# Altium schematic sheet COMMUNICATION.SchDoc
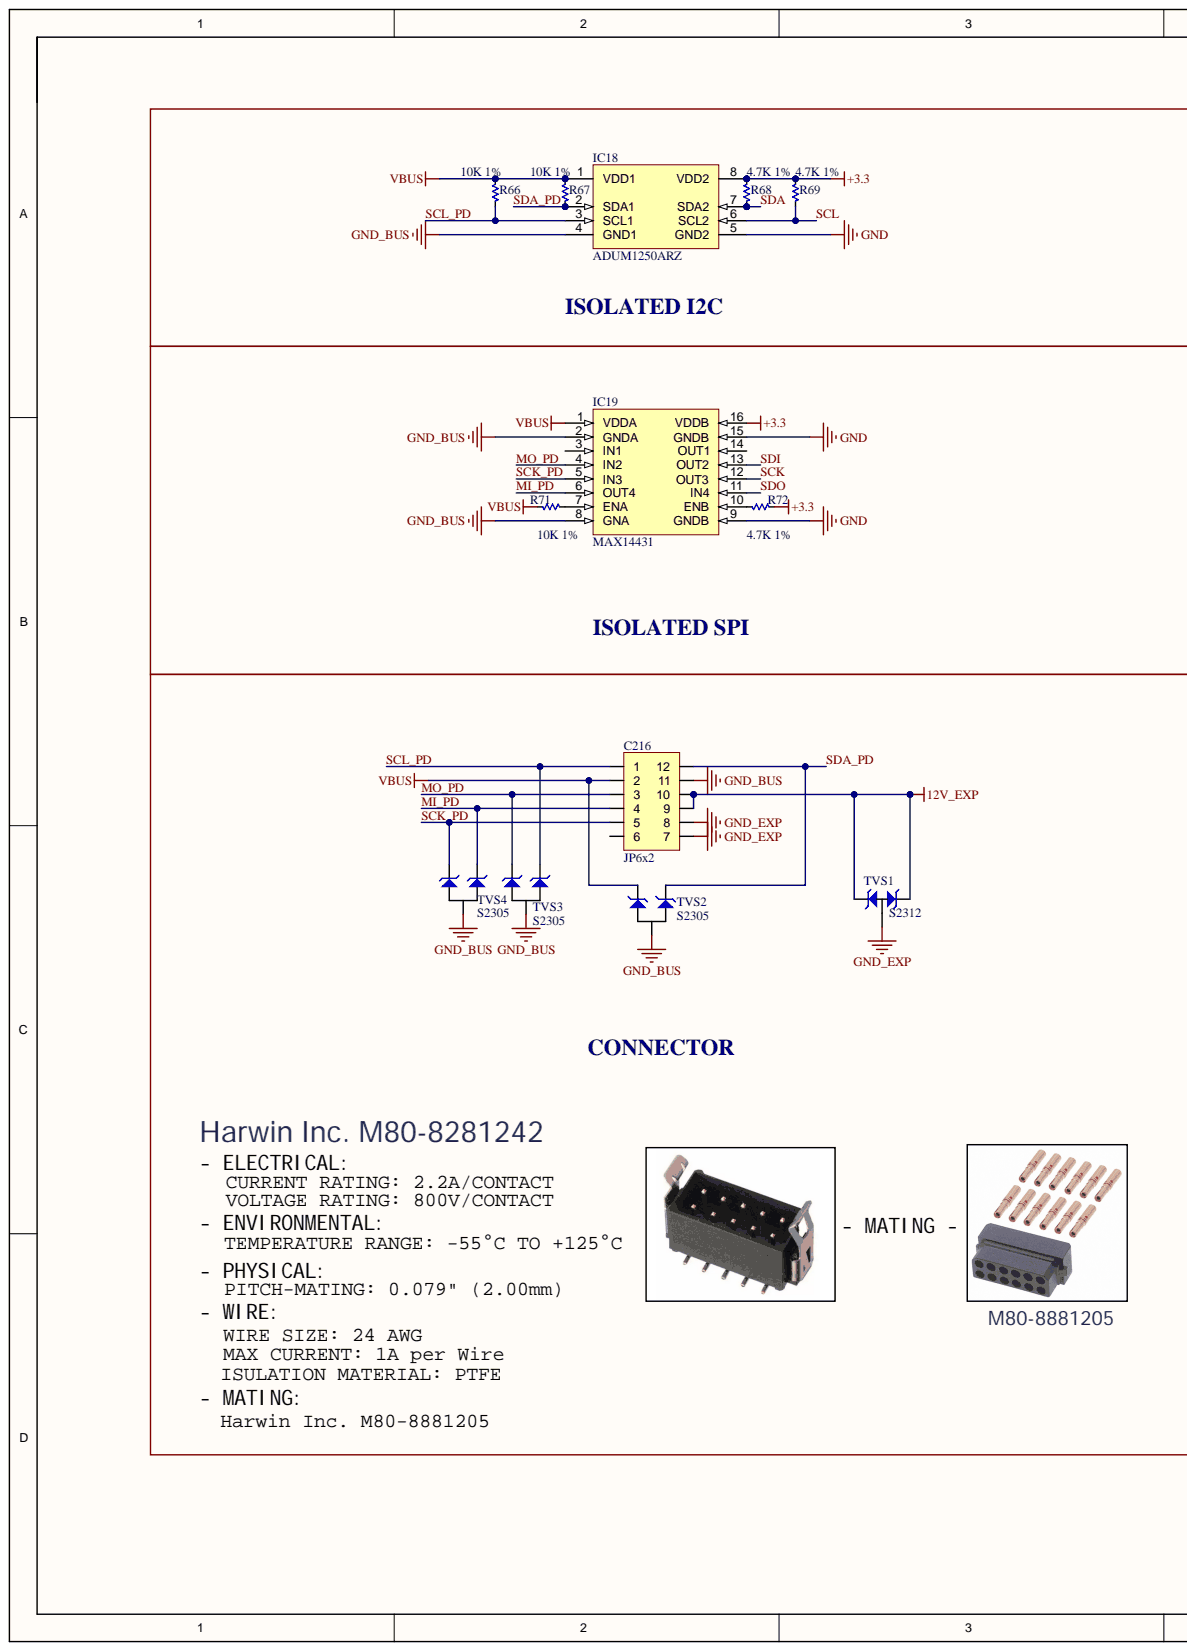
[diagram p0: COMMUNICATION.SchDoc, left]
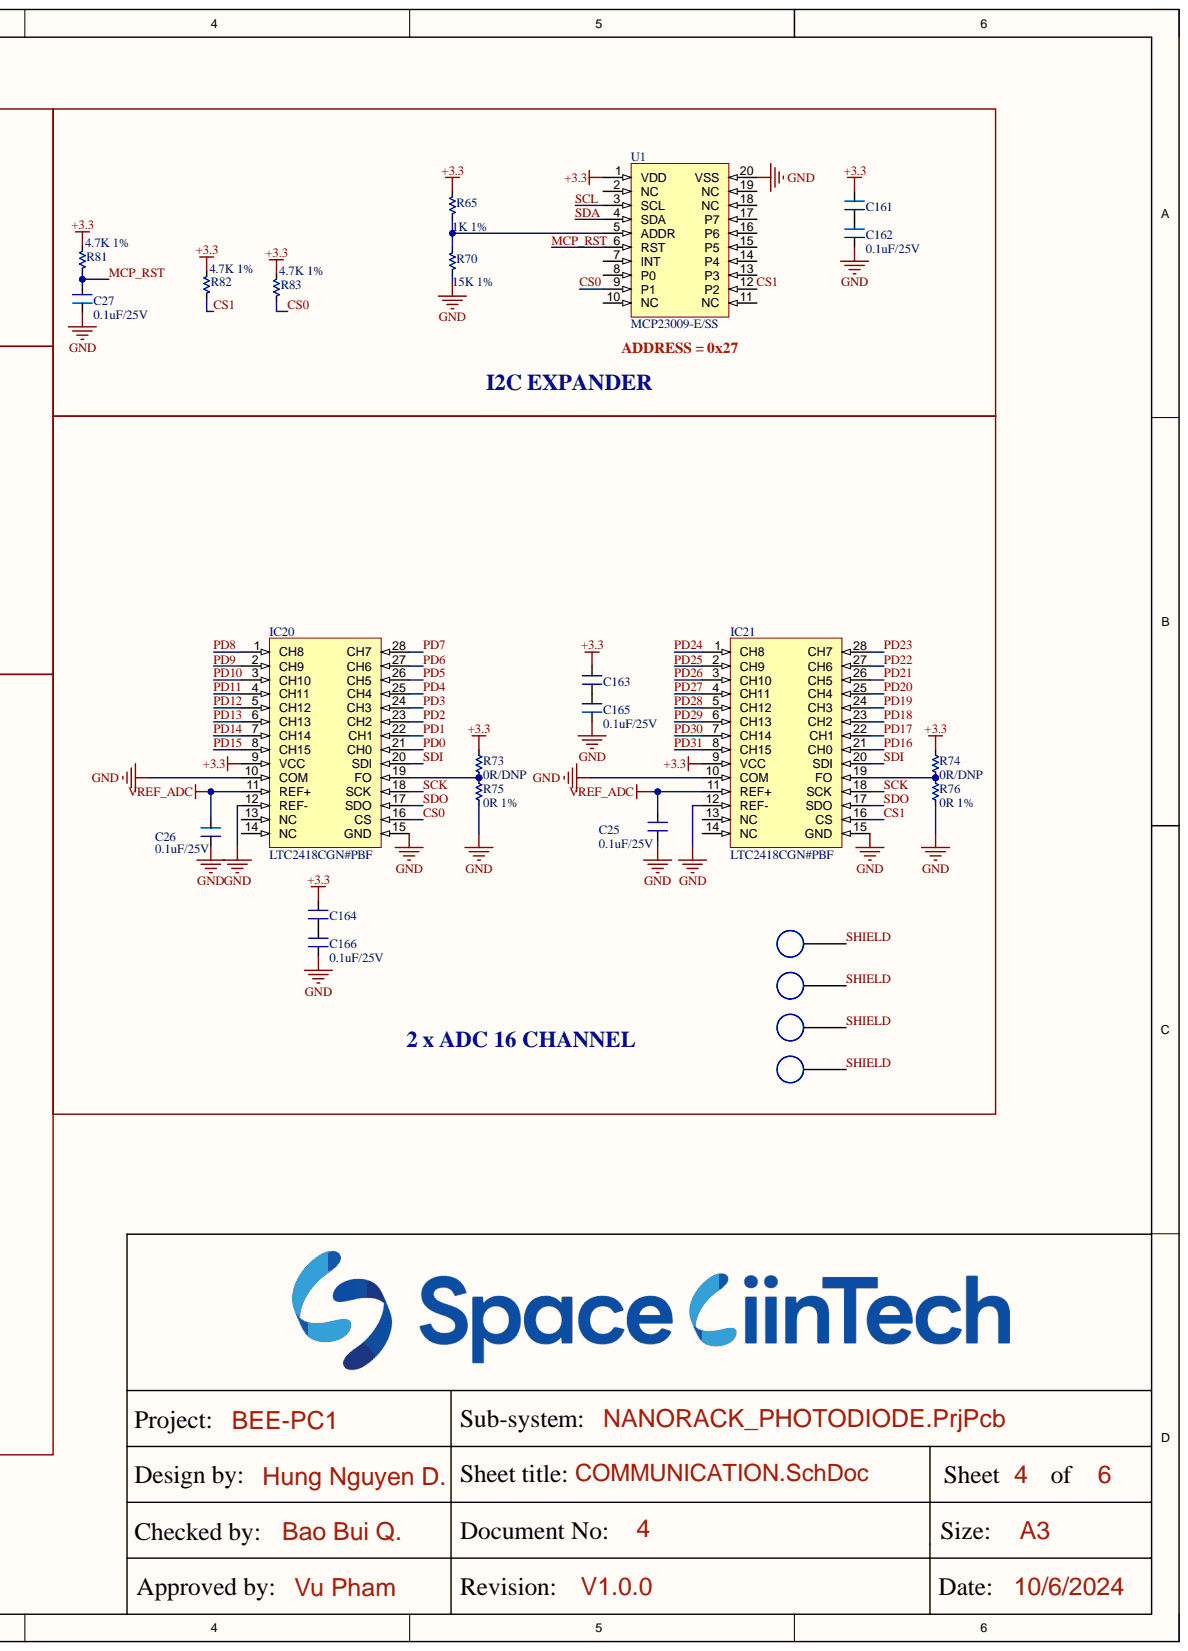
[diagram p1: COMMUNICATION.SchDoc, right]

## Parts
C25  0.1uF/25V  [SM-0603]
C26  0.1uF/25V  [SM-0603]
C27  0.1uF/25V  [SM-0603]
C161  0.1uF/25V  [SM-0603]
C162  0.1uF/25V  [SM-0603]
C163  0.1uF/25V  [SM-0603]
C164  0.1uF/25V  [SM-0603]
C165  0.1uF/25V  [SM-0603]
C166  0.1uF/25V  [SM-0603]
C216  JP6x2  [M80-8281242]
H1  M3  [M3]  (value not drawn)
H2  M3  [M3]  (value not drawn)
H3  M3  [M3]  (value not drawn)
H4  M3  [M3]  (value not drawn)
IC18  ADUM1250ARZ  [SO8]
IC19  MAX14431  [MAX14431]
IC20  LTC2418CGN#PBF  [LTC2418]
IC21  LTC2418CGN#PBF  [LTC2418]
R65  1K 1%  [RES-SMD-0603]
R66  10K 1%  [RES-SMD-0603]
R67  10K 1%  [RES-SMD-0603]
R68  4.7K 1%  [RES-SMD-0603]
R69  4.7K 1%  [RES-SMD-0603]
R70  15K 1%  [RES-SMD-0603]
R71  10K 1%  [RES-SMD-0603]
R72  4.7K 1%  [RES-SMD-0603]
R73  0R/DNP  [RES-SMD-0603]
R74  0R/DNP  [RES-SMD-0603]
R75  0R 1%  [RES-SMD-0603]
R76  0R 1%  [RES-SMD-0603]
R81  4.7K 1%  [RES-SMD-0603]
R82  4.7K 1%  [RES-SMD-0603]
R83  4.7K 1%  [RES-SMD-0603]
TVS1  S2312  [SOT23-123]
TVS2  S2305  [SOT23-123]
TVS3  S2305  [SOT23-123]
TVS4  S2305  [SOT23-123]
U1  MCP23009-E/SS  [SSOP20]

## Nets
12V_EXP: C216.9 C216.10 TVS1.1 TVS1.3
+3.3: C161.2 C163.2 C164.2 IC18.8(VDD2) IC19.16(VDDB) IC20.9(VCC) IC21.9(VCC) R65.2 R68.2 R69.2 R72.1 R73.1 R74.1 R81.2 R82.2 R83.2 U1.1(VDD)
GND: C25.1 C26.1 C27.1 C162.1 C165.1 C166.1 IC18.5(GND2) IC19.9(GNDB) IC19.15(GNDB) IC20.10(COM) IC20.12(REF-) IC20.15(GND) IC21.10(COM) IC21.12(REF-) IC21.15(GND) R70.1 R75.2 R76.2 U1.20(VSS)
GND_BUS: C216.11 IC18.4(GND1) IC19.2(GNDA) IC19.8(GNA) TVS2.2 TVS3.2 TVS4.2
GND_EXP: C216.7 C216.8 TVS1.2
VBUS: C216.2 IC18.1(VDD1) IC19.1(VDDA) R66.2 R67.2 R71.2 TVS2.3
VREF_ADC: C25.2 C26.2 IC20.11(REF+) IC21.11(REF+)
CS0: IC20.16(CS) R83.1 U1.9(P1)
CS1: IC21.16(CS) R82.1 U1.12(P2)
MCP_RST: C27.2 R81.1 U1.6(RST)
MI_PD: C216.4 IC19.6(OUT4) TVS4.3
MO_PD: C216.3 IC19.4(IN2) TVS3.1
PD0: IC20.21(CH0)
PD1: IC20.22(CH1)
PD2: IC20.23(CH2)
PD3: IC20.24(CH3)
PD4: IC20.25(CH4)
PD5: IC20.26(CH5)
PD6: IC20.27(CH6)
PD7: IC20.28(CH7)
PD8: IC20.1(CH8)
PD9: IC20.2(CH9)
PD10: IC20.3(CH10)
PD11: IC20.4(CH11)
PD12: IC20.5(CH12)
PD13: IC20.6(CH13)
PD14: IC20.7(CH14)
PD15: IC20.8(CH15)
PD16: IC21.21(CH0)
PD17: IC21.22(CH1)
PD18: IC21.23(CH2)
PD19: IC21.24(CH3)
PD20: IC21.25(CH4)
PD21: IC21.26(CH5)
PD22: IC21.27(CH6)
PD23: IC21.28(CH7)
PD24: IC21.1(CH8)
PD25: IC21.2(CH9)
PD26: IC21.3(CH10)
PD27: IC21.4(CH11)
PD28: IC21.5(CH12)
PD29: IC21.6(CH13)
PD30: IC21.7(CH14)
PD31: IC21.8(CH15)
SCK: IC19.12(OUT3) IC20.18(SCK) IC21.18(SCK)
SCK_PD: C216.5 IC19.5(IN3) TVS4.1
SCL: IC18.6(SCL2) R69.1 U1.3(SCL)
SCL_PD: C216.1 IC18.3(SCL1) R66.1 TVS3.3
SDA: IC18.7(SDA2) R68.1 U1.4(SDA)
SDA_PD: C216.12 IC18.2(SDA1) R67.1 TVS2.1
SDI: IC19.13(OUT2) IC20.20(SDI) IC21.20(SDI)
SDO: IC19.11(IN4) IC20.17(SDO) IC21.17(SDO)
SHIELD: H1.1 H2.1 H3.1 H4.1
NetC161_1: C161.1 C162.2
NetC163_1: C163.1 C165.2
NetC164_1: C164.1 C166.2
NetIC19_7: IC19.7(ENA) R71.1
NetIC19_10: IC19.10(ENB) R72.2
NetIC20_19: IC20.19(FO) R73.2 R75.1
NetIC21_19: IC21.19(FO) R74.2 R76.1
NetR65_1: R65.1 R70.2 U1.5(ADDR)

Not connected: C216 6; IC19 3(IN1) 14(OUT1); IC20 13(NC) 14(NC); IC21 13(NC) 14(NC); U1 2(NC) 7(INT) 8(P0) 10(NC) 11(NC) 13(P3) 14(P4) 15(P5) 16(P6) 17(P7) 18(NC) 19(NC)

## Library data of the parts
C25-C27 C161-C166 = CM: 0603; Value: 103; Component Kind: Standard; Library Name: Capacitors.SchLib
C216 = JP6x2: WR-PHD 2.54 mm Dual Socket Header; Manufacturer: W¨¹rth Elektronik eiSos GmbH; partNo: 61301221821; ComponentLink1 Description: Manufacturer; ComponentLink2 Description: Datasheet; NoPins: 12; Component Kind: Standard; ComponentLink1URL: http://www.we-online.com; ComponentLink2URL: http://katalog.we-online.de/em/datasheet/6130xx21821.pdf; Created Date: 29 March 2013; Library Name: Library.SchLib; Created by: Karrer Zheng
IC18 = ADUM1250: Component Kind: Standard; Library Name: Library.SchLib
IC19 = MAX14431: MAX14431CAEE+; Component Kind: Standard; Library Name: Library.SchLib
IC20 IC21 = LTC2418: Component Kind: Standard; Library Name: Library.SchLib
R65-R76 R81-R83 = Res-SMD: 0603; Manufacturer: Generic Components; Class: Passive; Component Kind: Standard; Library Name: Library.SchLib; Published: 08/06/2000; Publisher: Altium Hobart Technology Centre
TVS1 = S2315: TVS DIODE 12VWM 19VC SOT23, P/N:  S2312; Component Kind: Standard; Library Name: Library.SchLib
TVS2-TVS4 = S2303: TVS DIODE 5VWM 10.8VC SOT23, P/N:    S2305; Component Kind: Standard; Library Name: Library.SchLib
U1 = MCP23009: Component Kind: Standard; Library Name: Library.SchLib
H1-H4 = M3: Component Kind: Standard; Library Name: Library.SchLib
